# Altium schematic sheet pca20027 - sensors.SchDoc
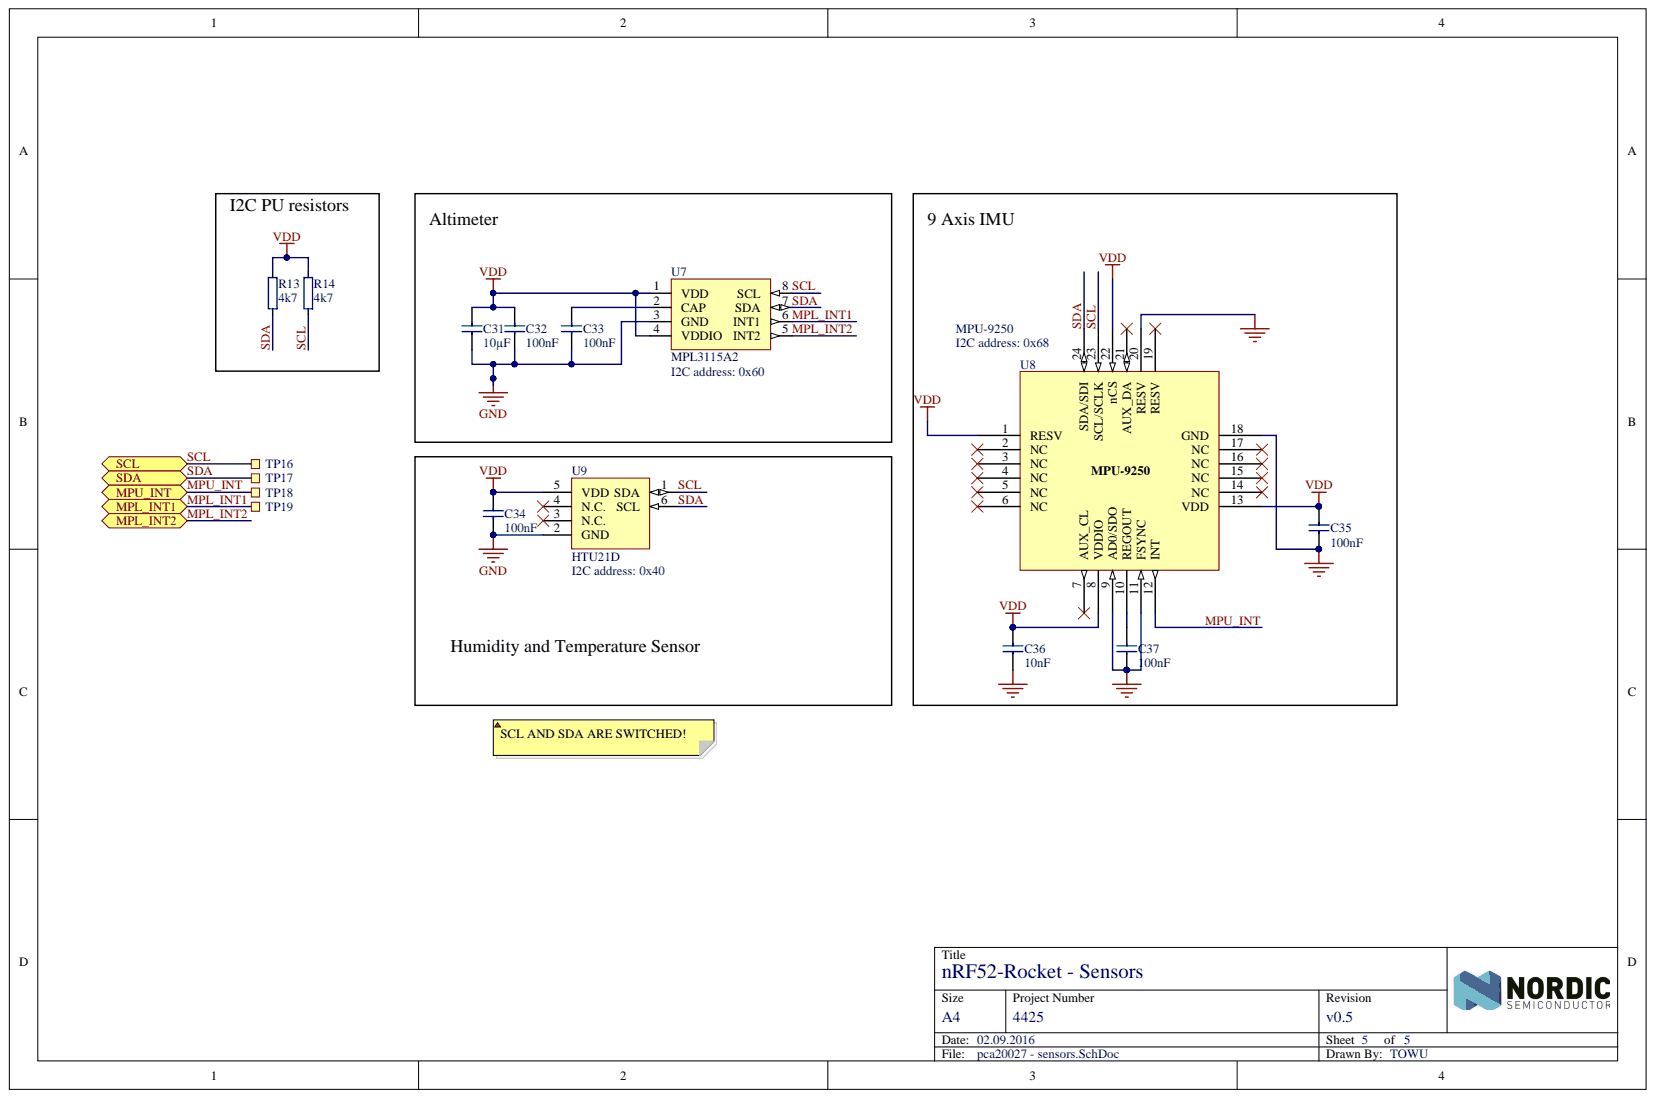
[diagram p0: pca20027 - sensors.SchDoc]

## Parts
C31  10µF  [CAPC1608X06L]
C32  100nF  [CAPC1608X06L]
C33  100nF  [CAPC1608X06L]
C34  100nF  [CAPC1608X06L]
C35  100nF  [CAPC1608X06L]
C36  10nF  [CAPC1608X06L]
C37  100nF  [CAPC1608X06L]
R13  4k7  [RESC1608X06L]
R14  4k7  [RESC1608X06L]
TP16  Ø1.0mm,SMD  [TP_SMD_Ø1.0mm]  (value not drawn)  (no BOM)
TP17  Ø1.0mm,SMD  [TP_SMD_Ø1.0mm]  (value not drawn)  (no BOM)
TP18  Ø1.0mm,SMD  [TP_SMD_Ø1.0mm]  (value not drawn)  (no BOM)
TP19  Ø1.0mm,SMD  [TP_SMD_Ø1.0mm]  (value not drawn)  (no BOM)
U7  MPL3115A2  [LGA125P300X500X120-8N]
U8  MPU-9250  [QFN40P300X300X105_HS-25N]
U9  HTU21D  [DFN100P300X300X121_HS-7N]

## Ports
MPL_INT1, MPL_INT2, MPU_INT, SCL, SDA

## Nets
GND: C31.1 C32.1 C33.1 C34.1 C35.1 C36.1 C37.1 U7.3(GND) U8.9(AD0/SDO) U8.11(FSYNC) U8.18(GND) U8.20(RESV) U9.2(GND) U9.7
VDD: C31.2 C32.2 C34.2 C35.2 C36.2 R13.1 R14.1 U7.1(VDD) U7.4(VDDIO) U8.1(RESV) U8.8(VDDIO) U8.13(VDD) U8.22(nCS) U9.5(VDD)
MPL_INT1: TP19.1 U7.6(INT1) ; port MPL_INT1
MPL_INT2: U7.5(INT2) ; port MPL_INT2
MPU_INT: TP18.1 U8.12(INT) ; port MPU_INT
SCL: R14.2 TP16.1 U7.8(SCL) U8.23(SCL/SCLK) U9.1(SDA) ; port SCL
SDA: R13.2 TP17.1 U7.7(SDA) U8.24(SDA/SDI) U9.6(SCL) ; port SDA
NetC33_2: C33.2 U7.2(CAP)
NetC37_2: C37.2 U8.10(REGOUT)

Not connected: U8 2(NC) 3(NC) 4(NC) 5(NC) 6(NC) 7(AUX_CL) 14(NC) 15(NC) 16(NC) 17(NC) 19(RESV) 21(AUX_DA); U9 3(N.C.) 4(N.C.)

## Library data of the parts
C31 = C0603-10µ: Capacitor, X5R, ±20%; Artikkelnr Noca: 20039234; Artikkelnr Simpro: N.A.; Database Table Name: Capacitors$; Note: 6.3V; Component Kind: Standard; Footprint Doc: 0603; LatestRevisionDate: 2011-01-17; Library Name: Nordic Passive DB.DbLib; Part Number: C0603-10µ; Pin Count: 2; Published: 2011-01-17; Value: 10µF; Publisher: Nordic Semiconductor
C32-C35 C37 = C0603-100n: Chip Cap 0603; Artikkelnr Noca: N.A.; Database Table Name: Capacitors$; Note: Chip Cap 1%; Artikkelnr Simpro: N.A.; Component Kind: Standard; Footprint Doc: 0603; LatestRevisionDate: 2009-05-08; Library Name: Nordic Passive DB.DbLib; Part Number: C0603-100n; Pin Count: 2; Published: 2009-05-08; Value: 100nF; Publisher: Nordic Semiconductor
C36 = C0603-10n: Chip Cap 0603; Artikkelnr Noca: N.A.; Database Table Name: Capacitors$; Note: Chip Cap 1%; Artikkelnr Simpro: N.A.; Component Kind: Standard; Footprint Doc: 0603; LatestRevisionDate: 2009-05-08; Library Name: Nordic Passive DB.DbLib; Part Number: C0603-10n; Pin Count: 2; Published: 2009-05-08; Value: 10nF; Publisher: Nordic Semiconductor
R13 R14 = R0603-4k7: Resistor, ±1%, 0.06W; Artikkelnr Noca: 27069089; Artikkelnr Simpro: N.A.; Database Table Name: Resistors$; Note: Chip Res 1%; Value Ohm: 4700; Component Kind: Standard; Footprint Doc: 0603; LatestRevisionDate: 2011-08-16; Library Name: Nordic Passive DB.DbLib; Part Number: R0603-4k7; Pin Count: 2; Published: 2009-05-08; Value: 4k7; Publisher: Nordic Semiconductor
TP16-TP19 = TP_SMD_Ø1.0MM: 1.0mm circular SMD testpad; Manufacturer: Nordic Semiconductor; Artikkelnr Noca: N.A.; Database Table Name: Connectors$; Artikkelnr Simpro: N.A.; Footprint Doc: TP_SMD_Ø1.0mm; LatestRevisionDate: 2013-06-11; Library Name: Nordic Passive DB.DbLib; Part Number: TP_SMD_Ø1.0MM; Pin Count: 1; Published: 2013-06-11; Value: Ø1.0mm,SMD; Publisher: Nordic Semiconductor
U7 = MPL3115A2: MEMS accurate Pressure/Altitude and Temperature sensor with I2C interface; 2nd source part number: MPL3115A2-ND; Manufacturer: Freescale; Artikkelnr Noca: N.A.; Database Table Name: Misc IC$; 2nd source Supplier: Digi-Key; Artikkelnr Simpro: N.A.; Component Kind: Standard; Datasheet: http://cache.freescale.com/files/sensors/doc/data_sheet/MPL3115A2.pdf?pspll=1; Footprint Doc: LGA-8; LatestRevisionDate: 2015-11-12; Library Name: Nordic Active DB.DbLib; Manufacturer Part Number: MPL3115A2; Part Number: MPL3115A2; Pin Count: 8; Published: 2013-09-012; Supplier: Farnell; Supplier part number: 200-9084; Value: MPL3115A2; Publisher: Nordic Semiconductor
U8 = MPU-9250: 9-axis MotionTracking™ Device; Manufacturer: InvenSense; Artikkelnr Noca: N.A.; Database Table Name: Misc IC$; Note: 3-Axis Gyro, 3-Axis Accel and 3-Axis Compass; Artikkelnr Simpro: N.A.; Component Kind: Standard; Datasheet: http://43zrtwysvxb2gf29r5o0athu.wpengine.netdna-cdn.com/wp-content/uploads/2015/02/MPU-9250-Datasheet.pdf; Footprint Doc: QFN-24; LatestRevisionDate: 2015-06-24; Library Name: Nordic Active DB.DbLib; Manufacturer Part Number: MPU-9250; Part Number: MPU-9250; Pin Count: 24; Published: 2015-06-24; Supplier: Digi-Key; Supplier part number: 1428-1019-1-ND; Value: MPU-9250; Publisher: Nordic Semiconductor
U9 = HTU21D: I2C Digital Relative Humidity sensor with Temperature output; 2nd source part number: 824-HTU21D; Manufacturer: Measurement Specialties; Artikkelnr Noca: N.A.; Database Table Name: Misc IC$; 2nd source Supplier: Mouser; Artikkelnr Simpro: N.A.; Component Kind: Standard; Datasheet: http://www.meas-spec.com/downloads/HTU21D.pdf; Footprint Doc: DFN-6; LatestRevisionDate: 2015-09-29; Library Name: Nordic Active DB.DbLib; Manufacturer Part Number: HTU21D; Part Number: HTU21D; Pin Count: 6; Published: 2015-09-29; Supplier: Digi-Key; Supplier part number: 223-1593-ND; Value: HTU21D; Publisher: Nordic Semiconductor
